# Altium schematic sheet Sheet1.SchDoc
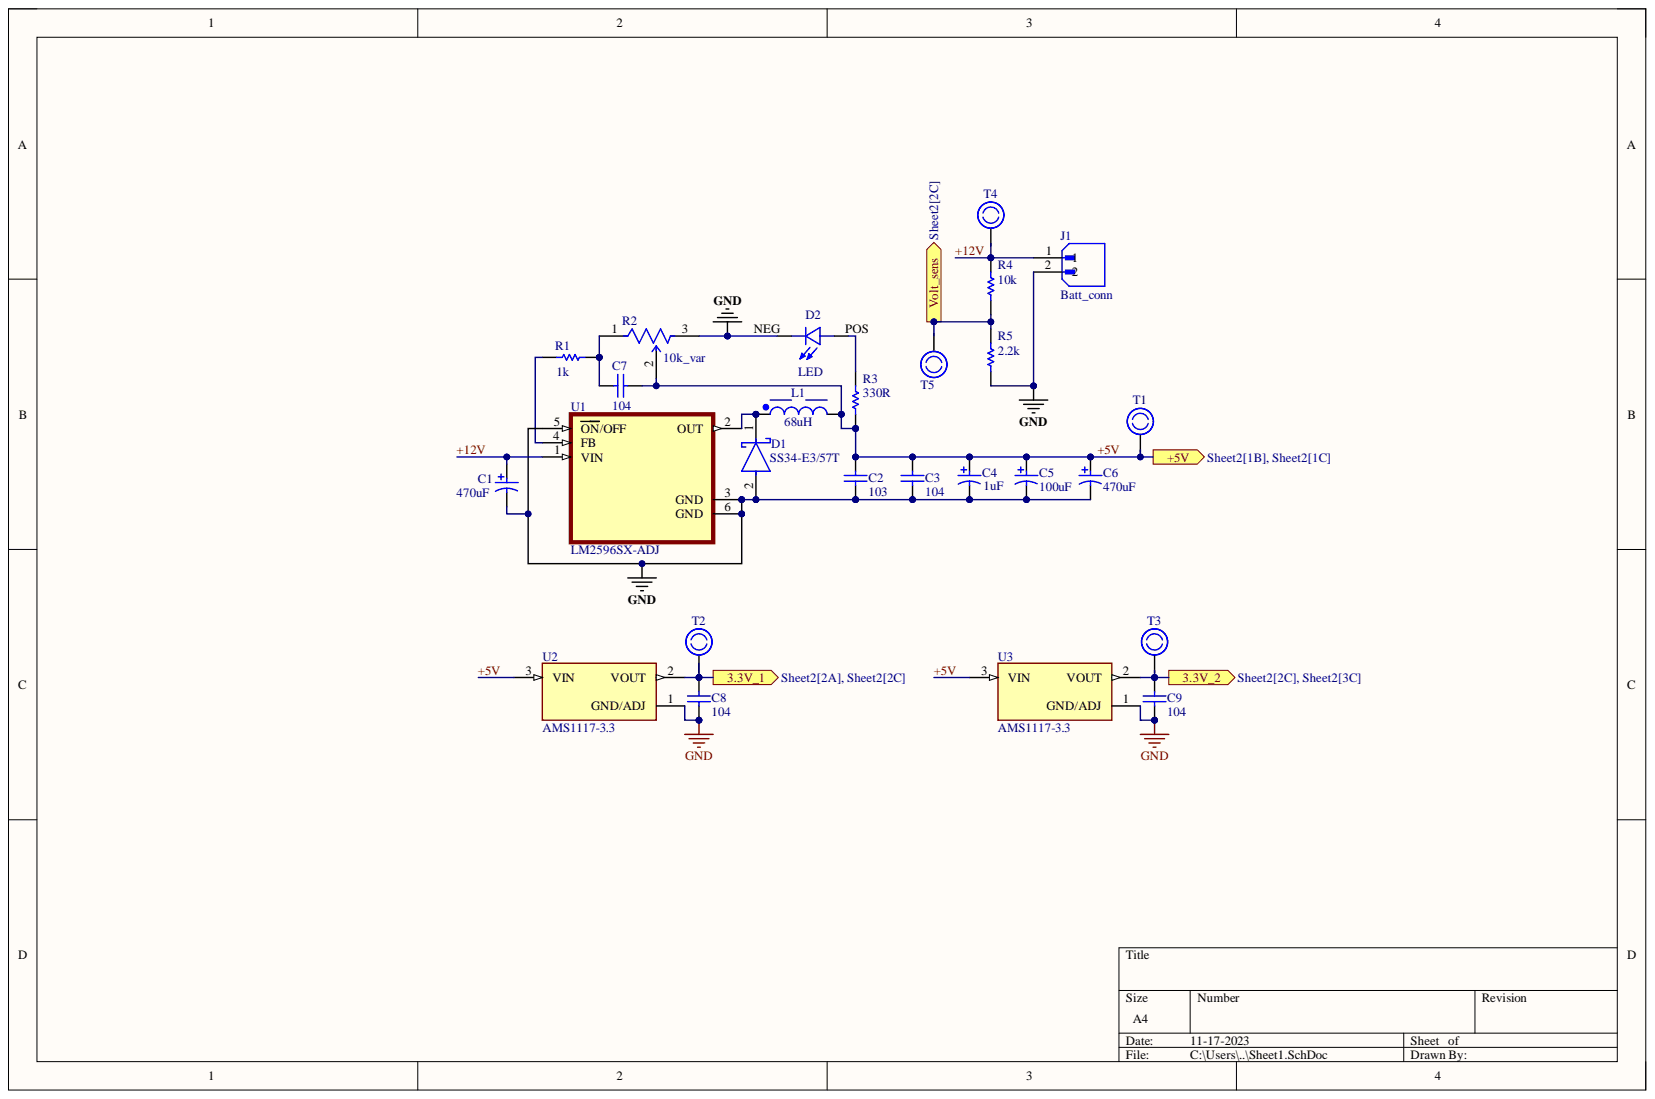
[diagram p0: Sheet1.SchDoc]

## Parts
C1  470uF  [PNSC-ECA-1VM221-2]
C2  103  [KEMT-1206-2_M]
C3  104  [KEMT-1206-2_M]
C4  1uF  [PNSC-ECA-1VM221-2]
C5  100uF  [PNSC-ECA-1VM221-2]
C6  470uF  [PNSC-ECA-1VM221-2]
C7  104  [KEMT-1206-2_M]
C8  104  [KEMT-1206-2_M]
C9  104  [KEMT-1206-2_M]
D1  SS34-E3/57T  [FP-95402-MFG]
D2  LED  [LEDC3216X120N]
J1  Batt_conn  [JST_B2B-XH-A(LF)(SN)]
L1  68uH  [WE-PD2_5848]
R1  1k  [RESC3216X70X50NL05T20]
R2  10k_var  [FP-3296Y-MFG]
R3  value 330R  [AXIAL-0.3]  (hidden comment: Res1)
R4  value 10k  [AXIAL-0.3]  (hidden comment: Res1)
R5  value 2.2k  [AXIAL-0.3]  (hidden comment: Res1)
T1  Test_point  [Testpoint]  (value not drawn)
T2  Test_point  [Testpoint]  (value not drawn)
T3  Test_point  [Testpoint]  (value not drawn)
T4  Test_point  [Testpoint]  (value not drawn)
T5  Test_point  [Testpoint]  (value not drawn)
U1  LM2596SX-ADJ  [VREG_LM2596SX-ADJ]
U2  AMS1117-3.3  [SOT229P700X180-4N]
U3  AMS1117-3.3  [SOT229P700X180-4N]

## Ports
3.3V_1, 3.3V_2, +5V, Volt_sens

## Nets
GND: C1.2 C2.1 C3.1 C4.2 C5.2 C6.2 C8.1 C9.1 D1.2 D2.NEG J1.2 R2.3 R5.1 U1.3(GND) U1.5(ON/OFF) U1.6(GND) U2.1 U3.1
3.3V_1: C8.2 U2.2 ; port 3.3V_1
3.3V_2: C9.2 U3.2 ; port 3.3V_2
+5V: C2.2 C3.2 C4.1 C5.1 C6.1 C7.2 L1.2 R2.2 R3.1 U2.3 U3.3 ; port +5V
+12V: C1.1 J1.1 R4.2 U1.1(VIN)
Volt_sens: R4.1 R5.2 ; port Volt_sens
NetC7_1: C7.1 R1.2 R2.1
NetD1_1: D1.1 L1.1 U1.2(OUT)
NetD2_POS: D2.POS R3.2
NetR1_1: R1.1 U1.4(FB)

Not connected: T1 0; T2 0; T3 0; T4 0; T5 0

## Library data of the parts
C1 C4-C6 = CMP-2000-05311-1: Aluminum Electrolytic Capacitor, 220 uF, +/- 20%, 35 V, -40 to 85 degC, 2-Pin THD, RoHS, Bulk; Manufacturer: Panasonic; Capacitance: 220µF; Lifetime@Temperature: 2000Hrs@85degC; Rated Voltage: 35V; Ripple Current: 350mA; Type: Radial; Case Size/Dimension: Ø8x11.5mm; Component Kind: Standard; Datasheet URL: https://datasheet.ciiva.com/26341/441851-da-01-en-elko-eca1vm221-m-r-220myf-35v-85c-26341906.pdf; Datasheet Version: Mar-06; Library Name: Altium Content Vault; Manufacturer URL: http://www.panasonic.com/; Operating Temp Range: -40to+85degC; Operating Temp-Max: 85degC; Package Description: 2-Pin Axial Lead, Dia 8 mm, Body Length 12.5 mm; Package Reference: ECA-1VM221; Series: M
C2 C3 C7-C9 = CMP-1037-04202-2: CAP, Ceramic, 0.1 uF, +/- 10%, 50 V, -55 to 125 degC, 1206 (3216 Metric), RoHS, Tape and Reel; Manufacturer: Kemet; Capacitance: 0.1µF; Capacitor Case Style: 1206[3216Metric]; Dielectric Characteristic: X7R; Product Range: KEMET-CSeries; SVHC: ToBeAdvised; Voltage Rating: 50V; Capacitance Tolerance: ±10%; Component Kind: Standard; Datasheet URL: https://datasheet.ciiva.com/6125/1725438-6125494.pdf; Datasheet Version: Jul-13; Library Name: Altium Content Vault; Manufacturer URL: http://www.kemet.com/; Operating Temperature Max: 125degC; Operating Temperature Min: -55degC; Package Description: 2-Leads SMD, Body 3.2 x 1.6 mm; Package Reference: 1206-2; Packaging: Tape&Reel
D1 = CMP-02539-000012-1: DIODE SCHOTTKY 40V 3A DO214AB; Manufacturer: Vishay; Max Junction Temp: +150°C; Max Surge Forward Current: 100A; Peak Reverse Current: 0.5mA; Standoff Height: 0mm; Automotive: No; Category: Diode; Component Kind: Standard; ComponentLink2Description: Datasheet; ComponentLink2URL: http://www.vishay.com/docs/88751/ss32.pdf; Device Class L1: Discrete Semiconductors; Device Class L2: Diodes; Device Class L3: Schottky Diodes; Digikey Description: DIODE SCHOTTKY 40V 3A DO214AB; Footprint Url: http://www.vishay.com/docs/95402/smc.pdf; Forward Current: 3A; Height: 2.62mm; Lead Free: Yes; Library Name: Altium Content Vault; Manufacturer Part Number: SS34-E3/57T; Max Repetitive Reverse Voltage: 40V; Mouser Description: Schottky Diodes & Rectifiers 3.0 Amp 40 Volt; Octopart: https://octopart.com/ss34-e3%2F57t-vishay-39552907; Package: 95402; RoHS: TRUE; Temperature: -55°C to +150°C; Temperature Range High: +150°C; Temperature Range Low: -55°C
D2 = LHN974_RED_LED_1206: 2.6 V Red LED SMD; MF: Osram; MP: LHN974 RED LED 1206; Availability: Not in stock; Check_prices: https://www.snapeda.com/parts/LHN974%20RED%20LED%201206/Osram/view-part/7899607/?ref=eda; Component Kind: Standard; Library Name: LHN974_RED_LED_1206.IntLib; Package: None; Price: None; SnapEDA_Link: https://www.snapeda.com/parts/LHN974%20RED%20LED%201206/Osram/view-part/7899607/?ref=snap
J1 = B2B-XH-A(LF)(SN): Connector Header Through Hole 2 position 0.098 (2.50mm); MF: JST Sales; Check_prices: https://www.snapeda.com/parts/B2B-XH-A(LF)(SN)/JST+Sales+America+Inc./view-part/?ref=eda; Component Kind: Standard; Library Name: B2B-XH-A_LF__SN_.IntLib; MP: B2B-XH-A(LF)(SN); Package: None; SnapEDA_Link: https://www.snapeda.com/parts/B2B-XH-A(LF)(SN)/JST+Sales+America+Inc./view-part/?ref=snap
L1 = CMP-0227-00424-2: NexarPartId: 7867905; Core Material: Ferrite; Current Rating: 640mA; DC Resistance (DCR): 313mΩ; Inductance: 68µH; Inductance Tolerance: 10%; Resistance: 460mΩ; Saturation Current: 860mA; Self Resonant Frequency: 10MHz; Shielding: Unshielded; Test Frequency: 10kHz; Ambient Temperature Range High: 85°C; Ambient Temperature Range Low: -40°C; Case/Package: Nonstandard; Component Kind: Standard; Composition: Wirewound; Depth: 5.2mm; Height: 4.5mm; Height - Seated (Max): 4.8514mm; Lead Free: Lead Free; Length: 5.2mm; Library Name: Altium Content Vault; Max DC Current: 640mA; Max Operating Temperature: 125°C; Min Operating Temperature: -40°C; Mount: Surface Mount; Number of Pins: 2; Packaging: Tape & Reel (TR); REACH SVHC: Unknown; RMS Current (Irms): 640mA; RoHS: Compliant; Termination: SMD/SMT; Tolerance: 10%; Width: 5.8mm
R1 = CMP-2003-00541-1: Manufacturer: Panasonic; Part Number: ERJ-8GEY0R00V; Component Kind: Standard; Library Name: Altium Content Vault
R2 = CMP-07234-000015-2: TRIMMER 10K OHM 0.5W PC PIN TOP; Manufacturer Series: 3296; Actuator Orientation: Vertical; Actuator Type: SCREW; Insulation Resistance: 1GR; Max Power Dissipation: 500mW; Number of Terminals/Pins: 3; Number of Turns: 25; Resistance: 10kR; Resistance Law: Linear; Resistance Tolerance: 10%; Temperature Coefficient: 100ppm/°C; Color: Blue; Component Kind: Standard; Depth: 4.83mm; Height: 0.395inch; Lead Free: Lead Free; Length: 9.53mm; Library Name: Altium Content Vault; Max Operating Temperature: 125°C; Military Standard: Not; Min Operating Temperature: -55°C; Mount: Panel; Number of Pins: 3; Package Quantity: 50; Packaging: Rail/Tube; Power Rating: 500mW; Radiation Hardening: No; REACH SVHC: No SVHC; Resistor Type: Cermet; RoHS Compliant: Yes; Series: 3296; Taper: Linear; Technology: CERMET; Terminal Finish: Tin; Termination: Pin; Tolerance: 10%; Type: Potentiometer; Weight: 0.03oz; Width: 9.53mm
R3-R5 = Res1: Resistor; Component Kind: Standard; LatestRevisionDate: 17-Jul-2002; LatestRevisionNote: Re-released for DXP Platform.; Library Name: Miscellaneous Devices.IntLib; PackageDescription: Axial Device, Thru-Hole; 2 Leads; 0.3 in Pin Spacing; PackageReference: AXIAL-0.3; Published: 8-Jun-2000; Signal Integrity: Res; Simulation: RESISTOR; Publisher: Altium Limited
U1 = LM2596SX-ADJ: SIMPLE SWITCHER&reg; 4.5V to 40V, 3A Low Component Count Step-Down Regulator 5-DDPAK/TO-263 -40 to 125; MF: Texas Instruments; Availability: In Stock; Check_prices: https://www.snapeda.com/parts/LM2596SX-ADJ/Texas+Instruments/view-part/?ref=eda; Component Kind: Standard; Library Name: LM2596SX-ADJ.IntLib; MP: LM2596SX-ADJ; Package: TO-263-5 Texas Instruments; Price: None; Purchase-URL: https://pricing.snapeda.com/search/part/LM2596SX-ADJ/?ref=eda; SnapEDA_Link: https://www.snapeda.com/parts/LM2596SX-ADJ/Texas+Instruments/view-part/?ref=snap
U2 U3 = AMS1117-3.3: Sot223/Pkg 1-Amp 3.3-Volt Low Drop Out Voltage Regulatator; MF: Advanced Monolithic Systems; Availability: Not in stock; Check_prices: https://www.snapeda.com/parts/AMS1117-3.3/Advanced+Monolithic+Systems/view-part/?ref=eda; Component Kind: Standard; Library Name: AMS1117-3.3.IntLib; MP: AMS1117-3.3; Package: SOT-223 Seeed Technology; Price: None; SnapEDA_Link: https://www.snapeda.com/parts/AMS1117-3.3/Advanced+Monolithic+Systems/view-part/?ref=snap
T1-T5 = Test_point: Component Kind: Standard; Library Name: Weather_Stn.SCHLIB
